# Altium schematic sheet Sch-QWA59_USB_Port.SchDoc
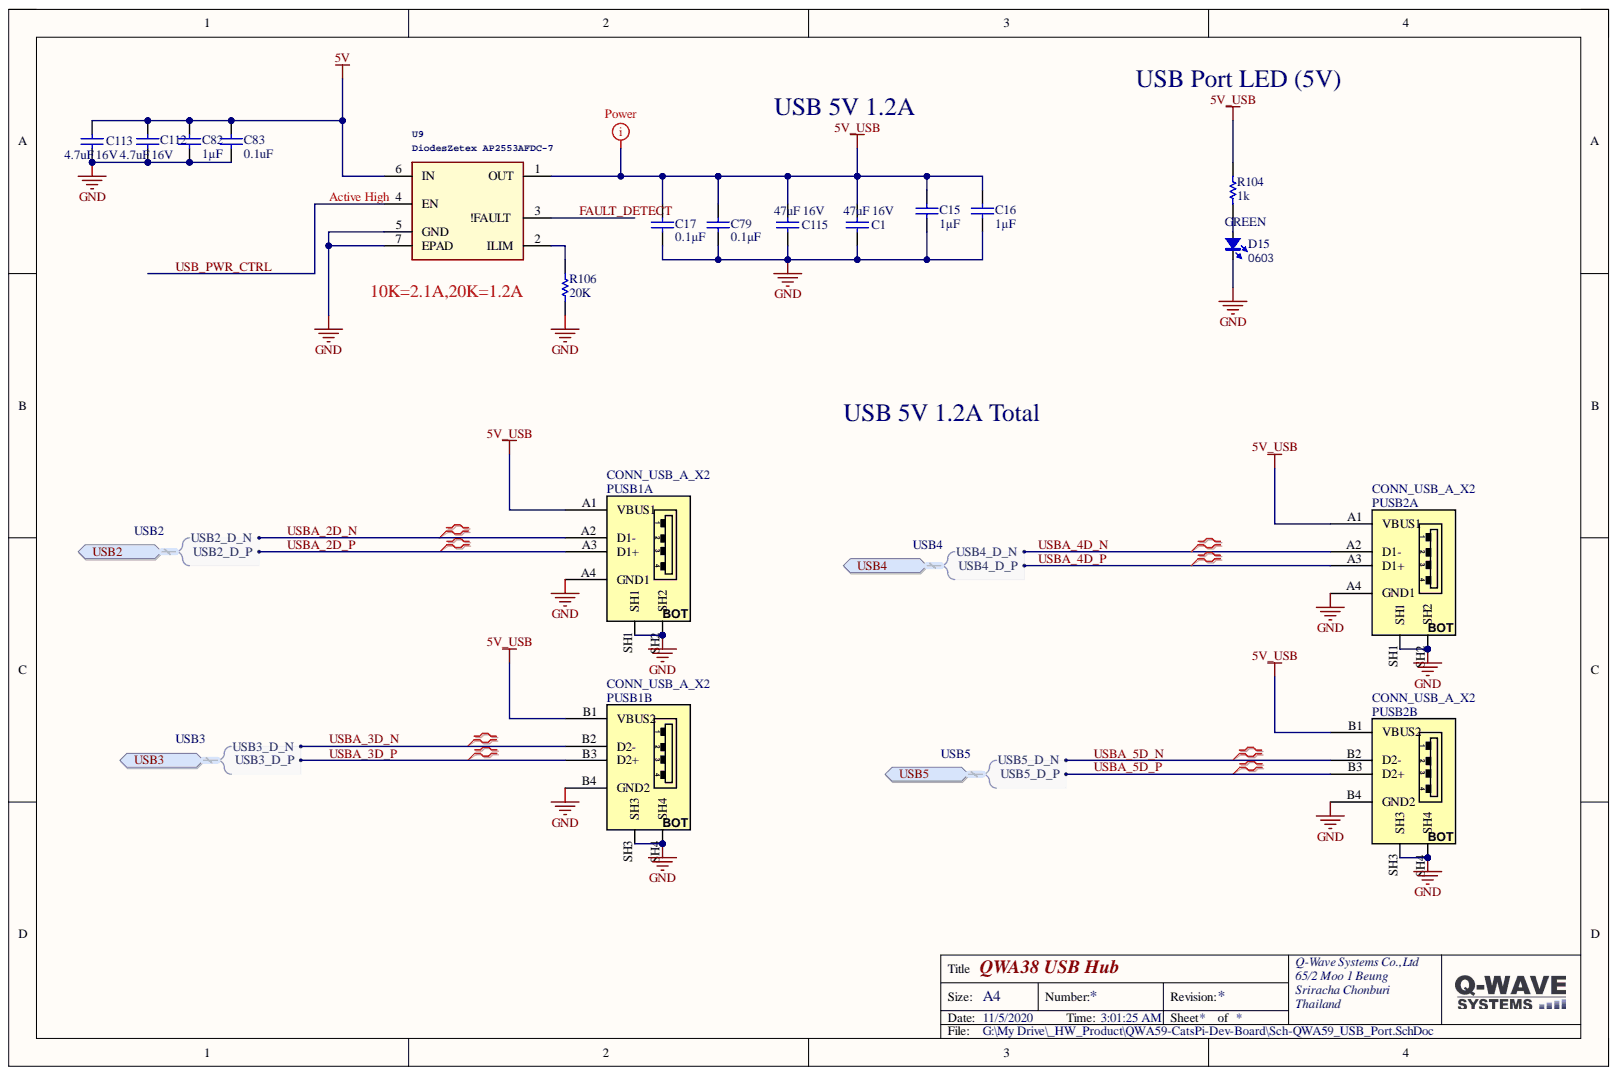
[diagram p0: Sch-QWA59_USB_Port.SchDoc]

## Parts
C1  47uF 16V  value 47uF  [1210C-GENERIC]
C15  1µF  [CAP_0603]
C16  1µF  [CAP_0603]
C17  0.1µF  [CAP_0603]
C79  0.1µF  [CAP_0603]
C82  1µF  [CAP_0603]
C83  0.1uF  [CAP_0603]
C112  4.7uF 16V  [CAP_0805]
C113  4.7uF 16V  [CAP_0805]
C115  47uF 16V  value 47uF  [1210C-GENERIC]
D15  GREEN  Type: 0603  [LED_0603_GREEN]
PUSB1  CONN_USB_A_X2  [USB_A_REC_RA_X2]  (parts A,B)
PUSB2  CONN_USB_A_X2  [USB_A_REC_RA_X2]  (parts A,B)
R104  1k  [RES_0603]
R106  20K  [RES_0603]
U9  DiodesZetex AP2553AFDC-7  [SON65P201X201X63-7N]

## Ports
USB2, USB2.USB2_D_N, USB2.USB2_D_P, USB3, USB3.USB3_D_N, USB3.USB3_D_P, USB4, USB4.USB4_D_N, USB4.USB4_D_P, USB5, USB5.USB5_D_N, USB5.USB5_D_P

## Nets
5V: C82.2 C83.2 C112.1 C113.1 U9.6(IN)
5V_USB: C1.2 C15.2 C16.2 C17.2 C79.2 C115.2 PUSB1.A1(VBUS1) PUSB1.B1(VBUS2) PUSB2.A1(VBUS1) PUSB2.B1(VBUS2) R104.2 U9.1(OUT)
GND: C1.1 C15.1 C16.1 C17.1 C79.1 C82.1 C83.1 C112.2 C113.2 C115.1 D15.2 PUSB1.A4(GND1) PUSB1.B4(GND2) PUSB1.SH1 PUSB1.SH2 PUSB1.SH3 PUSB1.SH4 PUSB2.A4(GND1) PUSB2.B4(GND2) PUSB2.SH1 PUSB2.SH2 PUSB2.SH3 PUSB2.SH4 R106.1 U9.5(GND) U9.7(EPAD)
FAULT_DETECT: U9.3(!FAULT)
USB_PWR_CTRL: U9.4(EN)
USBA_2D_N: PUSB1.A2(D1-) ; port USB2
USBA_2D_P: PUSB1.A3(D1+) ; port USB2
USBA_3D_N: PUSB1.B2(D2-) ; port USB3
USBA_3D_P: PUSB1.B3(D2+) ; port USB3
USBA_4D_N: PUSB2.A2(D1-) ; port USB4
USBA_4D_P: PUSB2.A3(D1+) ; port USB4
USBA_5D_N: PUSB2.B2(D2-) ; port USB5
USBA_5D_P: PUSB2.B3(D2+) ; port USB5
NetD15_1: D15.1 R104.1
NetR106_2: R106.2 U9.2(ILIM)

## Library data of the parts
C1 C115 = Cap: Capacitor 1210; Part Number: 0805F476M100NT; Component Kind: Standard; LatestRevisionDate: 17-Jul-2002; LatestRevisionNote: Re-released for DXP Platform.; Library Name: Miscellaneous Devices.IntLib; PackageDescription: Radial Cap, Thru-Hole; 2 Leads; 0.3 in Pin Spacing; PackageReference: RAD-0.3; Published: 8-Jun-2000; Signal Integrity: Cap; Simulation: CAP; Supplier: LCSC; Publisher: Altium Limited
C15 C16 C82 = Cap Semi: 1µF ±5% 50V Ceramic Capacitor X7R 0603; MFG: KEMET; MFG P/N: C0603C104J5RACTU; Component Kind: Standard; Library Name: Miscellaneous Devices.IntLib; Signal Integrity: Cap Semi; Simulation: CAP
C17 C79 = Cap Semi: 0.11µF ±5% 50V Ceramic Capacitor X7R 0603; MFG: KEMET; MFG P/N: C0603C104J5RACTU; Component Kind: Standard; Library Name: Miscellaneous Devices.IntLib; Signal Integrity: Cap Semi; Simulation: CAP
C83 = Cap Semi: 0.1µF ±5% 50V Ceramic Capacitor X7R 0603; MFG: KEMET; MFG P/N: C0603C104J5RACTU; Component Kind: Standard; Library Name: Miscellaneous Devices.IntLib; Signal Integrity: Cap Semi; Simulation: CAP
C112 C113 = Cap: 4.7µF ±10% 16V Ceramic Capacitor X5R 0805; MFG: KEMET; MFG P/N: C0603C475K8PACTU; Component Kind: Standard; Library Name: Miscellaneous Devices.IntLib; Signal Integrity: Cap; Simulation: CAP
D15 = LED_0603: LED; Value: color?; Component Kind: Standard; Library Name: SCH_Active.SchLib
PUSB1 PUSB2 = CONN_USB_A_X2: CONN, USB, A, RECEPTACLE, R/A, THD, DUAL; MANF: TE Connectivity; MANF_P#: 5787617-1; Component Kind: Standard; Library Name: QWA59.SchLib; VALUE: CONN_USB_A_X2
R104 = Res Semi: 1k Resistor 0603; Component Kind: Standard; Library Name: SCH_Passive.SchLib; Signal Integrity: Res Semi; Simulation: RES
R106 = Res Semi: Resistor 0603; Component Kind: Standard; Library Name: Miscellaneous Devices.IntLib; Signal Integrity: Res Semi; Simulation: RES
U9 = AP2553AFDC-7: Power Distribution 2.1A Precision ADJ 5.5V 2.1A; Manufacturer_Name: Diodes Inc.; GateName_1: G$1; Component Kind: Standard; DeviceSetName: AP2553AFDC-7; Height: 0.63mm; Library Name: AP2553AFDC-7.IntLib; LibraryName: AP2553AFDC-7; Manufacturer_Part_Number: AP2553AFDC-7; Mouser_Part_Number: 621-AP2553AFDC-7; Mouser_Price-Stock: https://www.mouser.com/Search/Refine.aspx?Keyword=621-AP2553AFDC-7; SymbolName_1: AP2553AFDC-7
